# Altium schematic sheet Ethernet.SchDoc
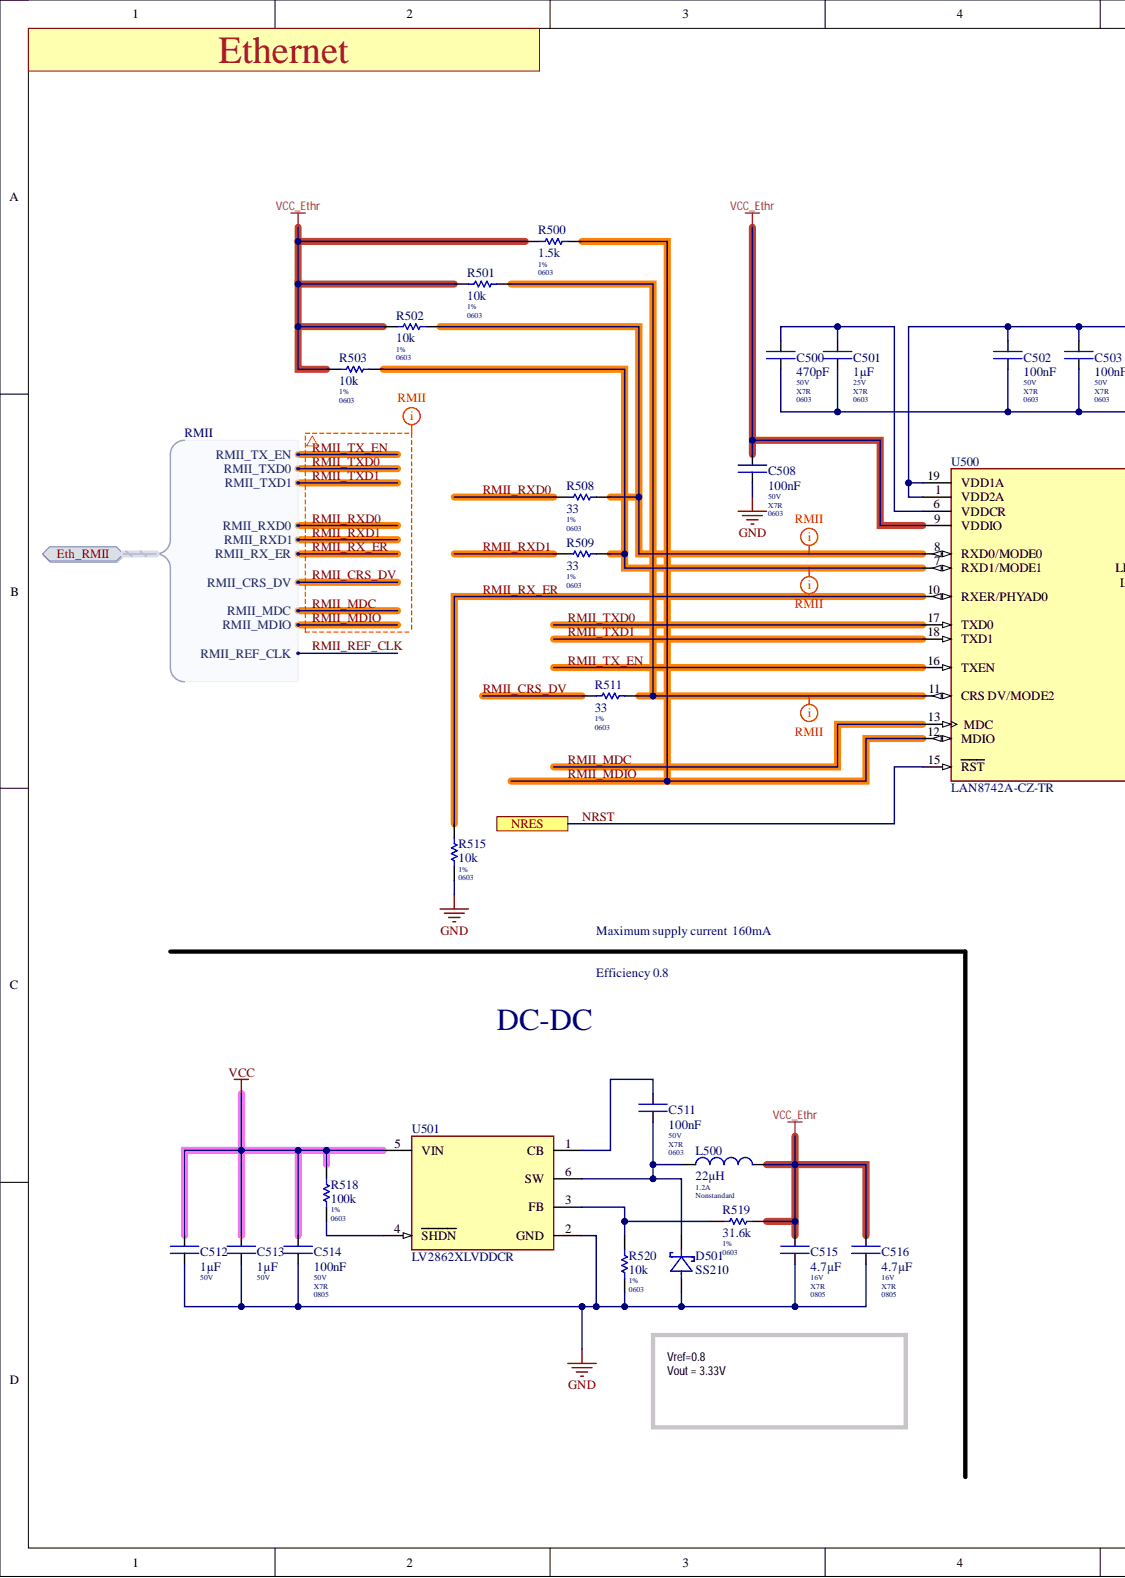
[diagram p0: Ethernet.SchDoc, left]
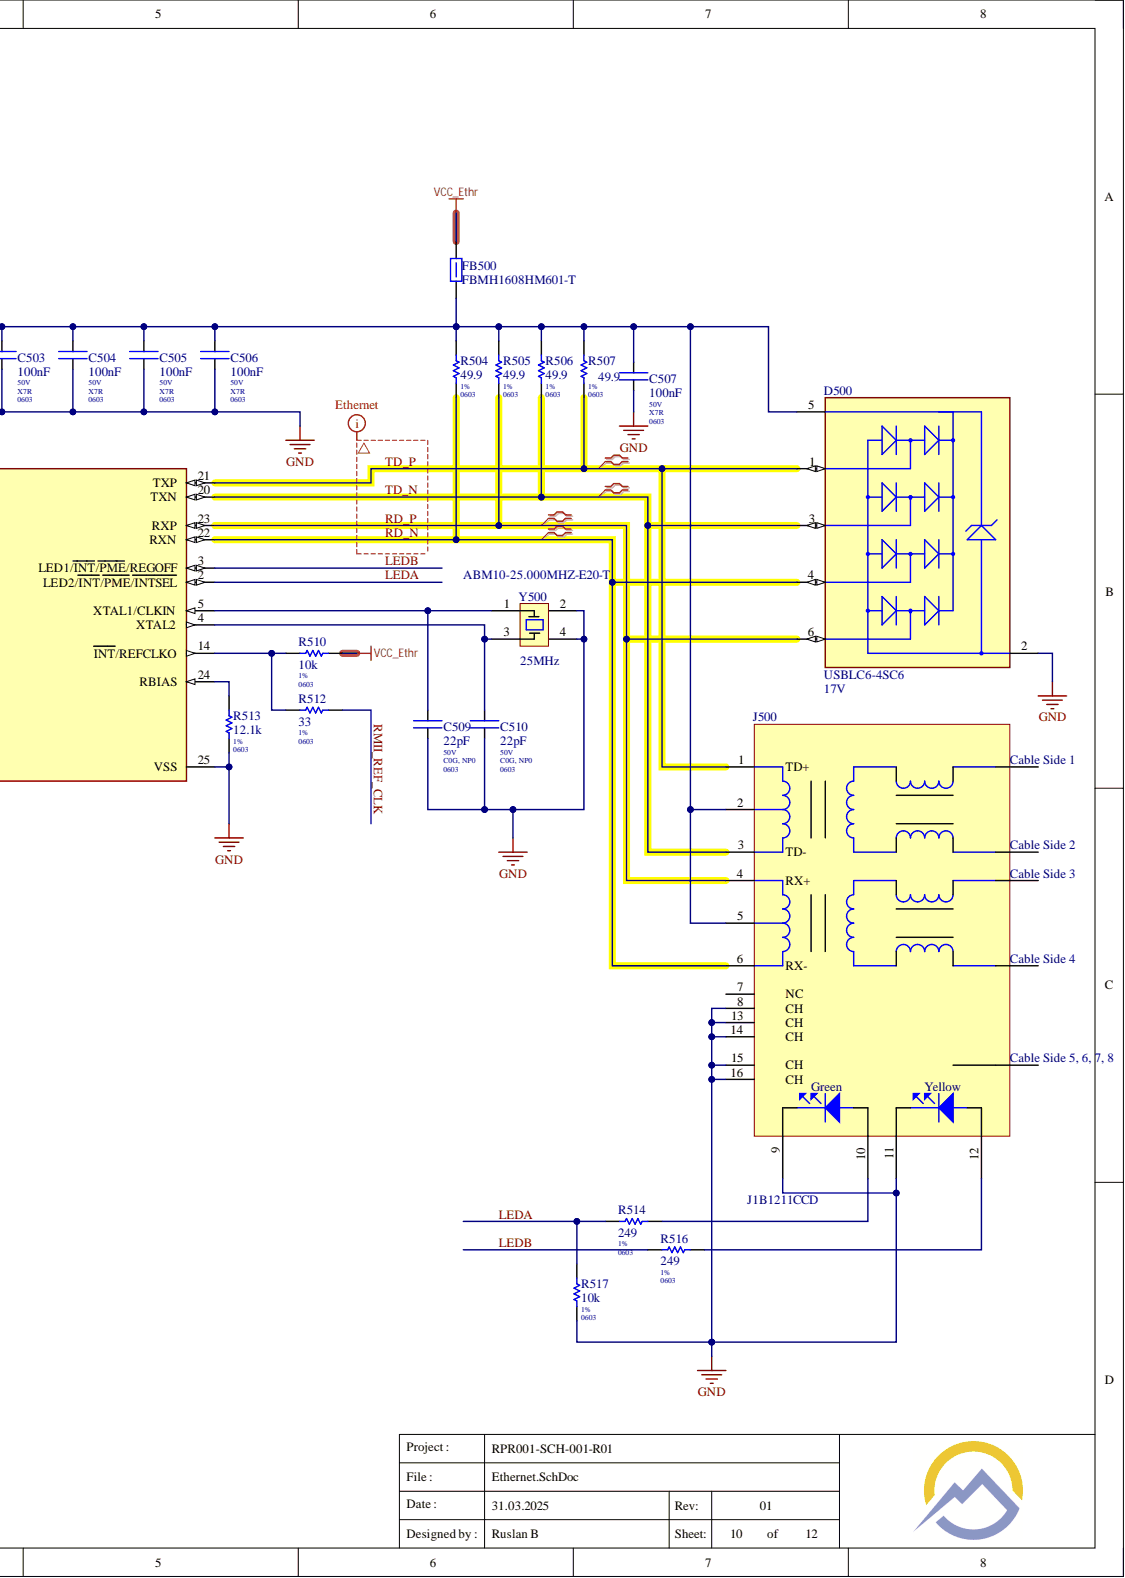
[diagram p1: Ethernet.SchDoc, right]

## Parts
C500  value 470pF  Case/Package: 0603  Dielectric: X7R  Voltage Rating: 50V  [B_Cap_0603_KEM]  (hidden comment: VJ0603Y471KLAAJ32)
C501  value 1µF  Case/Package: 0603  Dielectric: X7R  Voltage Rating: 25V  [B_Cap_0603_KEM]  (hidden comment: CL10B105KA8NNNC)
C502  value 100nF  Case/Package: 0603  Dielectric: X7R  Voltage Rating: 50V  [B_Cap_0603_KEM]  (hidden comment: GCM188R71H104KA57D)
C503  value 100nF  Case/Package: 0603  Dielectric: X7R  Voltage Rating: 50V  [B_Cap_0603_KEM]  (hidden comment: GCM188R71H104KA57D)
C504  value 100nF  Case/Package: 0603  Dielectric: X7R  Voltage Rating: 50V  [B_Cap_0603_KEM]  (hidden comment: GCM188R71H104KA57D)
C505  value 100nF  Case/Package: 0603  Dielectric: X7R  Voltage Rating: 50V  [B_Cap_0603_KEM]  (hidden comment: GCM188R71H104KA57D)
C506  value 100nF  Case/Package: 0603  Dielectric: X7R  Voltage Rating: 50V  [B_Cap_0603_KEM]  (hidden comment: GCM188R71H104KA57D)
C507  value 100nF  Case/Package: 0603  Dielectric: X7R  Voltage Rating: 50V  [B_Cap_0603_KEM]  (hidden comment: GCM188R71H104KA57D)
C508  value 100nF  Case/Package: 0603  Dielectric: X7R  Voltage Rating: 50V  [B_Cap_0603_KEM]  (hidden comment: GCM188R71H104KA57D)
C509  value 22pF  Case/Package: 0603  Voltage Rating: 50V  [B_Cap_0603_KEM]  (hidden comment: 06035A220JAT2A)
C510  value 22pF  Case/Package: 0603  Dielectric: C0G, NP0  Voltage Rating: 50V  [B_Cap_0603_KEM]  (hidden comment: 06035A220JAT2A)
C511  value 100nF  Voltage Rating: 50V  [B_Cap_0603_KEM]  (hidden comment: GCM188R71H104KA57D)
C512  value 1µF  Voltage Rating: 50V  [B_Cap_0805_KEM]  (hidden comment: CL21B105KBFNNNG)
C513  value 1µF  Voltage Rating: 50V  [B_Cap_0805_KEM]  (hidden comment: CL21B105KBFNNNG)
C514  value 100nF  Case/Package: 0805  Dielectric: X7R  Voltage Rating: 50V  [B_Cap_0805_KEM]  (hidden comment: CC0805KRX7R9BB104)
C515  value 4.7µF  Case/Package: 0805  Dielectric: X7R  Voltage Rating: 16V  [B_Cap_0805_KEM]  (hidden comment: GRM21BR71C475KE51L)
C516  value 4.7µF  Case/Package: 0805  Dielectric: X7R  Voltage Rating: 16V  [B_Cap_0805_KEM]  (hidden comment: GRM21BR71C475KE51L)
D500  USBLC6-4SC6  Clamping Voltage: 17V  [SOT-23-6]
D501  SS210  [DO-214AA, SMB]
FB500  FBMH1608HM601-T  [FBMH1608HM601-T-Footprint-1]
J500  J1B1211CCD  [J1B1211CCD-Footprint-1]
L500  value 22µH  Current Rating: 1.2A  [CDRH6D28NP]  (hidden comment: CDRH6D28NP-220NC)
R500  value 1.5k  Case/Package: 0603  Tolerance: 1%  [Res_0603_YAG]  (hidden comment: RC0603FR-071K5L)
R501  value 10k  Case/Package: 0603  Tolerance: 1%  [Res_0603_YAG]  (hidden comment: RC0603FR-0710KL)
R502  value 10k  Case/Package: 0603  Tolerance: 1%  [Res_0603_YAG]  (hidden comment: RC0603FR-0710KL)
R503  value 10k  Case/Package: 0603  Tolerance: 1%  [Res_0603_YAG]  (hidden comment: RC0603FR-0710KL)
R504  value 49.9  Case/Package: 0603  Tolerance: 1%  [Res_0603_YAG]  (hidden comment: RC0603FR-0749R9L)
R505  value 49.9  Case/Package: 0603  Tolerance: 1%  [Res_0603_YAG]  (hidden comment: RC0603FR-0749R9L)
R506  value 49.9  Case/Package: 0603  Tolerance: 1%  [Res_0603_YAG]  (hidden comment: RC0603FR-0749R9L)
R507  value 49.9  Case/Package: 0603  Tolerance: 1%  [Res_0603_YAG]  (hidden comment: RC0603FR-0749R9L)
R508  value 33  [Res_0603_YAG]  (hidden comment: RC0603FR-0733RL)
R509  value 33  Case/Package: 0603  Tolerance: 1%  [Res_0603_YAG]  (hidden comment: RC0603FR-0733RL)
R510  value 10k  [Res_0603_YAG]  (hidden comment: RC0603FR-0710KL)
R511  value 33  Case/Package: 0603  Tolerance: 1%  [Res_0603_YAG]  (hidden comment: RC0603FR-0733RL)
R512  value 33  Case/Package: 0603  Tolerance: 1%  [Res_0603_YAG]  (hidden comment: RC0603FR-0733RL)
R513  value 12.1k  Case/Package: 0603  Tolerance: 1%  [Res_0603_YAG]  (hidden comment: RC0603FR-0712K1L)
R514  value 249  Tolerance: 1%  [Res_0603_YAG]  (hidden comment: RC0603FR-07249RL)
R515  value 10k  Case/Package: 0603  Tolerance: 1%  [Res_0603_YAG]  (hidden comment: RC0603FR-0710KL)
R516  value 249  Case/Package: 0603  Tolerance: 1%  [Res_0603_YAG]  (hidden comment: RC0603FR-07249RL)
R517  value 10k  Case/Package: 0603  Tolerance: 1%  [Res_0603_YAG]  (hidden comment: RC0603FR-0710KL)
R518  value 100k  Case/Package: 0603  Tolerance: 1%  [Res_0603_YAG]  (hidden comment: RMCF0603FT100K)
R519  value 31.6k  [Res_0603_YAG]  (hidden comment: RMCF0603FT31K6)
R520  value 10k  Case/Package: 0603  Tolerance: 1%  [Res_0603_YAG]  (hidden comment: RC0603FR-0710KL)
U500  LAN8742A-CZ-TR  [24-VFQFN]
U501  LV2862XLVDDCR  [SOT-23-6]
Y500  ABM10-25.000MHZ-E20-T  Frequency: 25MHz  [4-SMD, No Lead]

## Ports
Eth_RMII, Eth_RMII.RMII_CRS_DV, Eth_RMII.RMII_MDC, Eth_RMII.RMII_MDIO, Eth_RMII.RMII_REF_CLK, Eth_RMII.RMII_RX_ER, Eth_RMII.RMII_RXD0, Eth_RMII.RMII_RXD1, Eth_RMII.RMII_TX_EN, Eth_RMII.RMII_TXD0, Eth_RMII.RMII_TXD1, NRES

## Nets
GND: C500.1 C501.1 C502.1 C503.1 C504.1 C505.1 C506.1 C507.1 C508.1 C509.1 C510.1 C512.2 C513.2 C514.1 C515.1 C516.1 D500.2 D501.2 J500.8 J500.9 J500.11 J500.13 J500.14 J500.15 J500.16 R513.1 R515.1 R517.1 R520.1 U500.25(VSS) U501.2(GND) Y500.2 Y500.4
VCC: C512.1 C513.1 C514.2 R518.2 U501.5(VIN)
VCC_Ethr: C508.2 C515.2 C516.2 FB500.2 L500.2 R500.1 R501.2 R502.2 R503.2 R510.1 R519.2 U500.9(VDDIO)
LEDA: R514.1 R517.2 U500.2(LED2/INT/PME/INTSEL)
LEDB: R516.1 U500.3(LED1/INT/PME/REGOFF)
NRST: U500.15(RST) ; port NRES
RD_N: D500.4 J500.6 R504.2 U500.22(RXN)
RD_P: D500.6 J500.4 R505.2 U500.23(RXP)
RMII_CRS_DV: R511.1 ; port Eth_RMII
RMII_MDC: U500.13(MDC) ; port Eth_RMII
RMII_MDIO: R500.2 U500.12(MDIO) ; port Eth_RMII
RMII_REF_CLK: R512.2 ; port Eth_RMII
RMII_RX_ER: R515.2 U500.10(RXER/PHYAD0) ; port Eth_RMII
RMII_RXD0: R508.1 ; port Eth_RMII
RMII_RXD1: R509.1 ; port Eth_RMII
RMII_TX_EN: U500.16(TXEN) ; port Eth_RMII
RMII_TXD0: U500.17(TXD0) ; port Eth_RMII
RMII_TXD1: U500.18(TXD1) ; port Eth_RMII
TD_N: D500.3 J500.3 R506.1 U500.20(TXN)
TD_P: D500.1 J500.1 R507.1 U500.21(TXP)
NetC500_2: C500.2 C501.2 U500.6(VDDCR)
NetC502_2: C502.2 C503.2 C504.2 C505.2 C506.2 C507.2 D500.5 FB500.1 J500.2 J500.5 R504.1 R505.1 R506.2 R507.2 U500.1(VDD2A) U500.19(VDD1A)
NetC509_2: C509.2 U500.5(XTAL1/CLKIN) Y500.1
NetC510_2: C510.2 U500.4(XTAL2) Y500.3
NetC511_1: C511.1 D501.1 L500.1 U501.6(SW)
NetC511_2: C511.2 U501.1(CB)
NetJ500_10: J500.10 R514.2
NetJ500_12: J500.12 R516.2
NetR501_1: R501.1 R511.2 U500.11(CRS_DV/MODE2)
NetR502_1: R502.1 R508.2 U500.8(RXD0/MODE0)
NetR503_1: R503.1 R509.2 U500.7(RXD1/MODE1)
NetR510_2: R510.2 R512.1 U500.14(INT/REFCLKO)
NetR513_2: R513.2 U500.24(RBIAS)
NetR518_1: R518.1 U501.4(SHDN)
NetR519_1: R519.1 R520.2 U501.3(FB)

Not connected: J500 7

## Library data of the parts
C500 = CMP-CAP-CER-00212-11: CAP CER 470 PF 50V X7R 0603 PB T; Manufacturer 1: Vishay; Tolerance: ±10%; Component Kind: Standard; ComponentLink1Description: Datasheet; ComponentLink1URL: https://www.vishay.com/docs/45200/vjhirelseries.pdf; Library Name: <Company> Server; Manufacturer Part Number 1: VJ0603Y471KLAAJ32
C501 = CMP-CAP-CER-00132-13: CL10B105KA8NNNC Samsung Ceramic Capacitor MLCC 1uF10% 25V X7R SMD 0603 (1608 Metric) RoHS; Manufacturer 1: Samsung Electro-Mechanics; Tolerance: 10%; Component Kind: Standard; Library Name: <Company> Server; Manufacturer Part Number 1: CL10B105KA8NNNC
C502-C508 C511 = CMP-CAP-CER-00027-13: CAP CER 0.1UF 50V X7R 0603; Manufacturer 1: Murata; Tolerance: ±10%; Component Kind: Standard; ComponentLink1Description: Datasheet; ComponentLink1URL: https://search.murata.co.jp/Ceramy/image/img/A01X/G101/ENG/GCM188R71H104KA57-01.pdf; Library Name: <Company> Server; Manufacturer Part Number 1: GCM188R71H104KA57D
  C511: Case/Package: 0603; Dielectric: X7R
C509 = CMP-CAP-CER-00161-12: CAP CER 22PF 50V C0G/NP0 0603; Manufacturer 1: Kyocera AVX; Dielectric: C0G, NP0; Tolerance: ±5%; Component Kind: Standard; ComponentLink1Description: Datasheet; ComponentLink1URL: https://datasheets.kyocera-avx.com/C0GNP0-Dielectric.pdf; Library Name: <Company> Server; Manufacturer Part Number 1: 06035A220JAT2A
C510 = CMP-CAP-CER-00161-12: CAP CER 22PF 50V C0G/NP0 0603; Manufacturer 1: Kyocera AVX; Tolerance: ±5%; Component Kind: Standard; ComponentLink1Description: Datasheet; ComponentLink1URL: https://datasheets.kyocera-avx.com/C0GNP0-Dielectric.pdf; Library Name: <Company> Server; Manufacturer Part Number 1: 06035A220JAT2A
C512 C513 = CMP-CAP-CER-00135-12: CAP CER 1UF 50V X7R 0805; Manufacturer 1: Samsung; Tolerance: ±10%; Component Kind: Standard; ComponentLink1Description: Datasheet; ComponentLink1URL: http://www.samsungsem.com/kr/support/product-search/mlcc/CL21B105KBFNNNG.jsp; Library Name: <Company> Server; Manufacturer Part Number 1: CL21B105KBFNNNG
C514 = CMP-CAP-CER-00029-14: Multilayer Ceramic Capacitor, 0.1 uF, 50 V, ï¿½ 10%, X7R, 0805 [2012 Metric]; Manufacturer 1: YAGEO; Component Kind: Standard; Library Name: <Company> Server; Manufacturer Part Number 1: CC0805KRX7R9BB104; Tolerance: 10%
C515 C516 = CMP-CAP-CER-00204-11: CAP CER 4.7UF 16V X7R 0805; Manufacturer 1: Murata; Tolerance: ±10%; Component Kind: Standard; ComponentLink1Description: Datasheet; ComponentLink1URL: https://search.murata.co.jp/Ceramy/image/img/A01X/G101/ENG/GRM21BR71C475KE51-01.pdf; Library Name: <Company> Server; Manufacturer Part Number 1: GRM21BR71C475KE51L
D500 = CMP-CPT-TVS-00084-1: USBLC6 Series 4 Line 6 V Uni / Bi-Directional ESD Protection - SOT-23-6; Manufacturer 1: STMicroelectronics; Manufacturer Part Number 1: USBLC6-2SC6; Breakdown Voltage: 6V; ESD Protection: Yes; Leakage Current: 150nA; Peak Pulse Current: 5A; Polarity: Unidirectional,Bidirectional; Reverse Standoff Voltage: 5V; Case/Package: SOT-23; Component Kind: Standard; Library Name: <Company> Server; Power Line Protection: Yes; Voltage Rating (DC): 5V; Working Voltage: 5V
D501 = CMP-DIO-SCH-00037-8: DIODE SCHOTTKY 100V 2A SMA; Manufacturer 1: Taiwan Semiconductor; Forward Voltage: 850mV; Max Reverse Current: 100µA; Average Rectified Current: 2A; Case/Package: DO-214AA; Component Kind: Standard; ComponentLink1Description: Datasheet; ComponentLink1URL: http://www.smc-diodes.com/propdf/SS22A%20THRU%20SS225A%20N1816%20REV.A.pdf; Forward Current: 2A; Library Name: <Company> Server; Manufacturer Part Number 1: SS210; Max Reverse Voltage (DC): 100V
FB500 = CMP-FIL-FB-00013-5: FERRITE BEAD 600 OHM 0603 1LN; Manufacturer 1: Taiyo Yuden; Current Rating: 700mA; Impedance: 600; Tolerance: 25%; Case/Package: 0603; Component Kind: Standard; ComponentLink1Description: Datasheet; ComponentLink1URL: https://ds.yuden.co.jp/TYCOMPAS/ut/detail?pn=FBMH1608HM601-T  &u=M; Library Name: <Company> Server; Manufacturer Part Number 1: FBMH1608HM601-T
J500 = CMP-CON-INF-00012-5: CONN MAGJACK 1PORT 100BASE-T PCB; Manufacturer 1: WIZnet; Connector Type: RJ45; Gender: Female; Number of Contacts: 8; Number of Ports: 1; Number of Terminals/Pins: 4; Component Kind: Standard; ComponentLink1Description: Datasheet; ComponentLink1URL: http://wizwiki.net/wiki/lib/exe/fetch.php?media=products:wiz550web:wiz550webds_kr:j1b1211ccd.pdf; Library Name: <Company> Server; Manufacturer Part Number 1: J1B1211CCD; Mount: TH; Orientation: Horizontak
L500 = CMP-IND-00067-9: FIXED IND 22UH 1.2A 128 MOHM SMD; Manufacturer 1: Sumida; DC Resistance (DCR): 0.128; Shielding: Shielded; Tolerance: 30%; Case/Package: Nonstandard; Component Kind: Standard; ComponentLink1Description: Datasheet; ComponentLink1URL: http://products.sumida.com/products/pdf/CDRH6D28.pdf; Library Name: <Company> Server; Manufacturer Part Number 1: CDRH6D28NP-220NC
R500 = CMP-RES-SMD-00543-2: SMD Chip Resistor, 1.5 kOhm, ± 1%, 100 mW, 0603 [1608 Metric], Thick Film, General Purpose; Manufacturer 1: Yageo; Power Rating: 100mW; Temperature Coefficient: 100ppm/°C; Voltage Rating: 50V; Component Kind: Standard; Library Name: <Company> Server; Manufacturer Part Number 1: RC0603FR-071K5L
R501-R503 R510 R515 R517 R520 = CMP-RES-SMD-00183-9: RES SMD 10K OHM 1% 1/10W 0603; Manufacturer 1: Yageo; Temperature Coefficient: ±100ppm/℃; Component Kind: Standard; ComponentLink1Description: Datasheet; ComponentLink1URL: https://www.yageo.com/upload/media/product/productsearch/datasheet/rchip/PYu-RC_Group_51_RoHS_L_10.pdf; Library Name: <Company> Server; Manufacturer Part Number 1: RC0603FR-0710KL
  R510: Case/Package: 0603; Tolerance: 1%
R504-R506 = CMP-RES-SMD-00353-9: RES SMD 49.9 OHM 1% 1/10W 0603; Manufacturer 1: Yageo; Temperature Coefficient: ±100ppm/℃; Component Kind: Standard; ComponentLink1Description: Datasheet; ComponentLink1URL: http://www.yageo.com/documents/recent/PYu-RC_Group_51_RoHS_L_10.pdf; Library Name: <Company> Server; Manufacturer Part Number 1: RC0603FR-0749R9L
R507 = CMP-RES-SMD-00353-9: RES SMD 49.9 OHM 1% 1/10W 0603; Manufacturer 1: Yageo; Temperature Coefficient: ±100ppm/℃; Value: 49.9; Component Kind: Standard; ComponentLink1Description: Datasheet; ComponentLink1URL: http://www.yageo.com/documents/recent/PYu-RC_Group_51_RoHS_L_10.pdf; Library Name: <Company> Server; Manufacturer Part Number 1: RC0603FR-0749R9L
R508 R509 R511 R512 = CMP-RES-SMD-00094-11: RES 33 OHM 1% 1/10W 0603; Manufacturer 1: Yageo; Temperature Coefficient: ±100ppm/℃; Component Kind: Standard; ComponentLink1Description: Datasheet; ComponentLink1URL: https://www.yageo.com/upload/media/product/productsearch/datasheet/rchip/PYu-RC_Group_51_RoHS_L_11.pdf; Library Name: <Company> Server; Manufacturer Part Number 1: RC0603FR-0733RL
  R508: Case/Package: 0603; Tolerance: 1%
R513 = CMP-RES-SMD-00194-9: RES SMD 12.1K OHM 1% 1/10W 0603; Manufacturer 1: Yageo; Temperature Coefficient: ±100ppm/℃; Component Kind: Standard; ComponentLink1Description: Datasheet; ComponentLink1URL: http://www.yageo.com/documents/recent/PYu-RC_Group_51_RoHS_L_10.pdf; Library Name: <Company> Server; Manufacturer Part Number 1: RC0603FR-0712K1L
R514 R516 = CMP-RES-SMD-00474-3: Res Thick Film 0603 249 Ohm 1% 0.1W(1/10W) ±100ppm/°C Pad SMD T/R; Manufacturer 1: Yageo; Power Rating: 100mW; Voltage Rating: 50V; Component Kind: Standard; Library Name: <Company> Server; Manufacturer Part Number 1: RC0603FR-07249RL; Temperature Coefficient: 100ppm/°C
  R514: Case/Package: 0603
R518 = CMP-RES-SMD-00047-11: RES 100K OHM 1% 1/10W 0603; Manufacturer 1: Stackpole Electronics; Temperature Coefficient: ±100ppm/℃; Component Kind: Standard; ComponentLink1Description: Datasheet; ComponentLink1URL: https://www.seielect.com/catalog/sei-rmcf_rmcp.pdf; Library Name: <Company> Server; Manufacturer Part Number 1: RMCF0603FT100K
R519 = CMP-RES-SMD-00091-11: RES 31.6K OHM 1% 1/10W 0603; Manufacturer 1: Stackpole Electronics; Temperature Coefficient: ±100ppm/℃; Case/Package: 0603; Component Kind: Standard; ComponentLink1Description: Datasheet; ComponentLink1URL: https://www.seielect.com/catalog/sei-rmcf_rmcp.pdf; Library Name: <Company> Server; Manufacturer Part Number 1: RMCF0603FT31K6; Tolerance: 1%
U500 = CMP-IC-INF-00025-2: Small Footprint Rmii 10/100 Ethernet Transceiver 24 QFN 4X4X0.9MM T/r Rohs Compliant: Yes; Manufacturer 1: Microchip; Data Rate: 100Mbps; Max Supply Voltage: 3.3V; Min Supply Voltage: 1.8V; Number of Transceivers: 1; Case/Package: QFN; Component Kind: Standard; Interface: Ethernet; Library Name: <Company> Server; Manufacturer Part Number 1: LAN8742A-CZ-TR; Supply Voltage: 3.3V
U501 = CMP-POW-VOLR-SW-00041-4: 4.5-V to 60-V, 600-mA buck converter in ultra-small SOT23 package 6-SOT-23-THIN -40 to 125; Manufacturer 1: Texas Instruments; Max Input Voltage: 60V; Min Input Voltage: 4V; Output Current: 600mA; Output Type: Adjustable; Switching Frequency: 2.1MHz; Synchronous Rectifier: No; Case/Package: SOT-23-6 Thin, TSOT-23-6; Component Kind: Standard; Library Name: <Company> Server; Manufacturer Part Number 1: LV2862XLVDDCR; Output Voltage: 60V
Y500 = CMP-CRaO-CR-00007-5: CRYSTAL 25.0000MHZ 10PF SMD; Manufacturer 1: Abracon; ESR (Equivalent Series Resistance): 100; Frequency Stability: ±20ppm; Component Kind: Standard; ComponentLink1Description: Datasheet; ComponentLink1URL: https://abracon.com/Resonators/ABM10.pdf; Library Name: <Company> Server; Load Capacitance: 10pF; Manufacturer Part Number 1: ABM10-25.000MHZ-E20-T
